# Altium schematic sheet MCU_and_Antenna.SchDoc (continued)
## Library data of the parts (continued)
R4 = RC0402JR-070RL: GENERAL PURPOSE CHIP RESISTOR Metal Glaze/thick Film, 0.0625W, 0ohm, Surface Mount, 0402; Manufacturer: Yageo Group; Max Power Dissipation: 63mW; Power Rating: 62.5mW; Temperature Coefficient: 200ppm/°C; Tolerance: 5%; Voltage Rating: 50V; Case/Package: 0402; Category: Chip SMD Resistors; China RoHS: Non-Compliant; Component Kind: Standard; ComponentLink1Description: https://datasheet.octopart.com:443/RC0402JR-070RL-Yageo-datasheet-182189538.pdf?src-supplier=IHS; ComponentLink1URL: https://datasheet.octopart.com:443/RC0402JR-070RL-Yageo-datasheet-182189538.pdf?src-supplier=IHS; ComponentLink2Description: https://datasheet.octopart.com:443/RC0402JR-070RL-Yageo-datasheet-10408619.pdf?src-supplier=Newark; ComponentLink2URL: https://datasheet.octopart.com:443/RC0402JR-070RL-Yageo-datasheet-10408619.pdf?src-supplier=Newark; ComponentLink3Description: https://datasheet.octopart.com:443/RC0402JR-070RL-Yageo-Group-datasheet-181964826.pdf?src-supplier=Newark; ComponentLink3URL: https://datasheet.octopart.com:443/RC0402JR-070RL-Yageo-Group-datasheet-181964826.pdf?src-supplier=Newark; ComponentLink4Description: https://datasheet.octopart.com:443/RC0402JR-070RL-Yageo-Group-datasheet-190305687.pdf?src-supplier=Future+Electronics; ComponentLink4URL: https://datasheet.octopart.com:443/RC0402JR-070RL-Yageo-Group-datasheet-190305687.pdf?src-supplier=Future+Electronics; ComponentLink5Description: https://datasheet.octopart.com:443/RC0402JR-070RL-Yageo-datasheet-164759695.pdf?src-supplier=Future+Electronics; ComponentLink5URL: https://datasheet.octopart.com:443/RC0402JR-070RL-Yageo-datasheet-164759695.pdf?src-supplier=Future+Electronics; ComponentLink6Description: https://datasheet.octopart.com:443/RC0402JR-070RL-Yageo-datasheet-137700087.pdf?src-supplier=Future+Electronics; ComponentLink6URL: https://datasheet.octopart.com:443/RC0402JR-070RL-Yageo-datasheet-137700087.pdf?src-supplier=Future+Electronics; ComponentLink7Description: https://datasheet.octopart.com:443/RC0402JR-070RL-Yageo-datasheet-12531192.pdf?src-supplier=Seeed+Studio; ComponentLink7URL: https://datasheet.octopart.com:443/RC0402JR-070RL-Yageo-datasheet-12531192.pdf?src-supplier=Seeed+Studio; ComponentLink8Description: https://datasheet.octopart.com:443/RC0402JR-070RL-Yageo-datasheet-8324527.pdf?src-supplier=TME; ComponentLink8URL: https://datasheet.octopart.com:443/RC0402JR-070RL-Yageo-datasheet-8324527.pdf?src-supplier=TME; ComponentLink9Description: https://datasheet.octopart.com:443/RC0402JR-070RL-Yageo-datasheet-62067350.pdf?src-supplier=Farnell; ComponentLink9URL: https://datasheet.octopart.com:443/RC0402JR-070RL-Yageo-datasheet-62067350.pdf?src-supplier=Farnell; ComponentLink10Description: https://datasheet.octopart.com:443/RC0402JR-070RL-Yageo-datasheet-8329306.pdf?src-supplier=element14; ComponentLink10URL: https://datasheet.octopart.com:443/RC0402JR-070RL-Yageo-datasheet-8329306.pdf?src-supplier=element14; ComponentLink11Description: https://datasheet.octopart.com:443/RC0402JR-070RL-Yageo-datasheet-505231.pdf?src-supplier=element14; ComponentLink11URL: https://datasheet.octopart.com:443/RC0402JR-070RL-Yageo-datasheet-505231.pdf?src-supplier=element14; ComponentLink12Description: https://datasheet.octopart.com:443/RC0402JR-070RL-Yageo-datasheet-175423674.pdf?src-supplier=SOS+electronic; ComponentLink12URL: https://datasheet.octopart.com:443/RC0402JR-070RL-Yageo-datasheet-175423674.pdf?src-supplier=SOS+electronic; ComponentLink13Description: https://datasheet.octopart.com/RC0402JR-070RL-Yageo-datasheet-73766026.pdf; ComponentLink13URL: https://datasheet.octopart.com/RC0402JR-070RL-Yageo-datasheet-73766026.pdf; ComponentLink14Description: http://sigma.octopart.com:80/conflict_mineral_statement/Yageo-company-3.pdf?src-supplier=Compliance+Statements; ComponentLink14URL: http://sigma.octopart.com:80/conflict_mineral_statement/Yageo-company-3.pdf?src-supplier=Compliance+Statements; ComponentLink15Description: http://sigma.octopart.com:80/rohs_statement/Yageo-company-2.pdf?src-supplier=Compliance+Statements; ComponentLink15URL: http://sigma.octopart.com:80/rohs_statement/Yageo-company-2.pdf?src-supplier=Compliance+Statements; Composition: Thick Film; Contact Plating: Tin; Depth: 500µm; Features: Moisture Resistant; Height: 350µm; LCSC Part: C60485; Lead Free: Lead Free; Length: 1mm; Library Name: Schlib.SchLib; Lifecycle Status: Production; Manufacturer Part Number: RC0402JR-070RL; Max Operating Temperature: 155°C; Military Standard: Not; Min Operating Temperature: -55°C; Mounting Technology: Surface Mount; Number of Terminals: 2; Number of Terminations: 2; Packaging: Tape & Reel; Pins: 2; Pricing: 1=0,1, 10=0,010, 25=0,0064, 50=0,0056, 100=0,0048, 250=0,00404, 500=0,00356, 1000=0,00313, 5000=0,00237 (USD); Radiation Hardening: No; REACH SVHC: Yes; RoHS: Compliant; Schedule B: 8533290000, 8533290000|8533290000, 8533290000|8533290000|8533290000, 8533290000|8533290000|8533290000|8533290000; Stock: 12719023; Supplier: DigiKey; Supplier Part Number: 311-0.0JRDKR-ND; Termination: SMD/SMT; Voltage Rating (DC): 50V; Width: 500µm
SW1 = 436331045822: Wurth Elektronik tactile switch, Solder terminal, size 4.5 x 4.7mm, surface, SPST; Manufacturer Lifecycle Status: ACTIVE; Contact Current Rating: 50mA; Contact Resistance: 100mΩ; Life (Cycles): 100kcycles; Voltage Rating: 12V; YEOL: 1 = Estimated lifetime > 10 years; Category: Tactile Switches; Component Kind: Standard; ComponentLink1Description: https://datasheet.octopart.com:443/436331045822-W%C3%BCrth-Elektronik-datasheet-154248855.pdf?src-supplier=Wurth+Elektronik; ComponentLink1URL: https://datasheet.octopart.com:443/436331045822-W%C3%BCrth-Elektronik-datasheet-154248855.pdf?src-supplier=Wurth+Elektronik; ComponentLink2Description: https://datasheet.octopart.com:443/436331045822-W%C3%BCrth-Elektronik-datasheet-141445726.pdf?src-supplier=HQonline; ComponentLink2URL: https://datasheet.octopart.com:443/436331045822-W%C3%BCrth-Elektronik-datasheet-141445726.pdf?src-supplier=HQonline; ComponentLink3Description: https://datasheet.octopart.com:443/436331045822-W%C3%BCrth-Elektronik-Midcom-datasheet-10030364.pdf?src-supplier=element14+APAC; ComponentLink3URL: https://datasheet.octopart.com:443/436331045822-W%C3%BCrth-Elektronik-Midcom-datasheet-10030364.pdf?src-supplier=element14+APAC; ComponentLink4Description: https://datasheet.octopart.com:443/436331045822-W%C3%BCrth-Elektronik-datasheet-41196550.pdf?src-supplier=element14+APAC; ComponentLink4URL: https://datasheet.octopart.com:443/436331045822-W%C3%BCrth-Elektronik-datasheet-41196550.pdf?src-supplier=element14+APAC; Contact Plating: Silver; Current Rating: 50mA; Height: 1.65mm; Insulation Resistance: 100MΩ; LCSC Part: C5143619; Library Name: Schlib.SchLib; Lifecycle Status: Production; Manufacturer: Wurth Elektronik; Manufacturer Part Number: 436331045822; Max Operating Temperature: 85°C; Min Operating Temperature: -40°C; Mounting Technology: Surface Mount; Packaging: Tape and Reel; Pricing: 3300=0,32965 (USD); RoHS: Compliant; Stock: 30789; Supplier: DigiKey; Supplier Part Number: 732-7059-2-ND; Voltage Rating (DC): 12V; Width: 4.7mm
U2 = ESP32-S3-PICO-1-N8R2: ESP32-S3-PICO-1 Is A System-in-p; Manufacturer: Espressif Systems; Category: RF Transceiver Modules and Modems; Component Kind: Standard; Library Name: Schlib.SchLib; Manufacturer Part Number: ESP32-S3-PICO-1-N8R2; Pricing: 1=6,1, 10=5,281, 25=4,9968, 100=4,6063, 250=4,37224, 500=4,20692, 1000=4,05122 (USD); Stock: 1099; Supplier: DigiKey; Supplier Part Number: 1965-ESP32-S3-PICO-1-N8R2DKR-ND
C8 = C0402-NC: Component Kind: Standard; Library Name: Schlib.SchLib
L2 = L0402_NC: Component Kind: Standard; Library Name: Schlib.SchLib
